# Altium schematic sheet Main0.3.SchDoc(Main0.3)
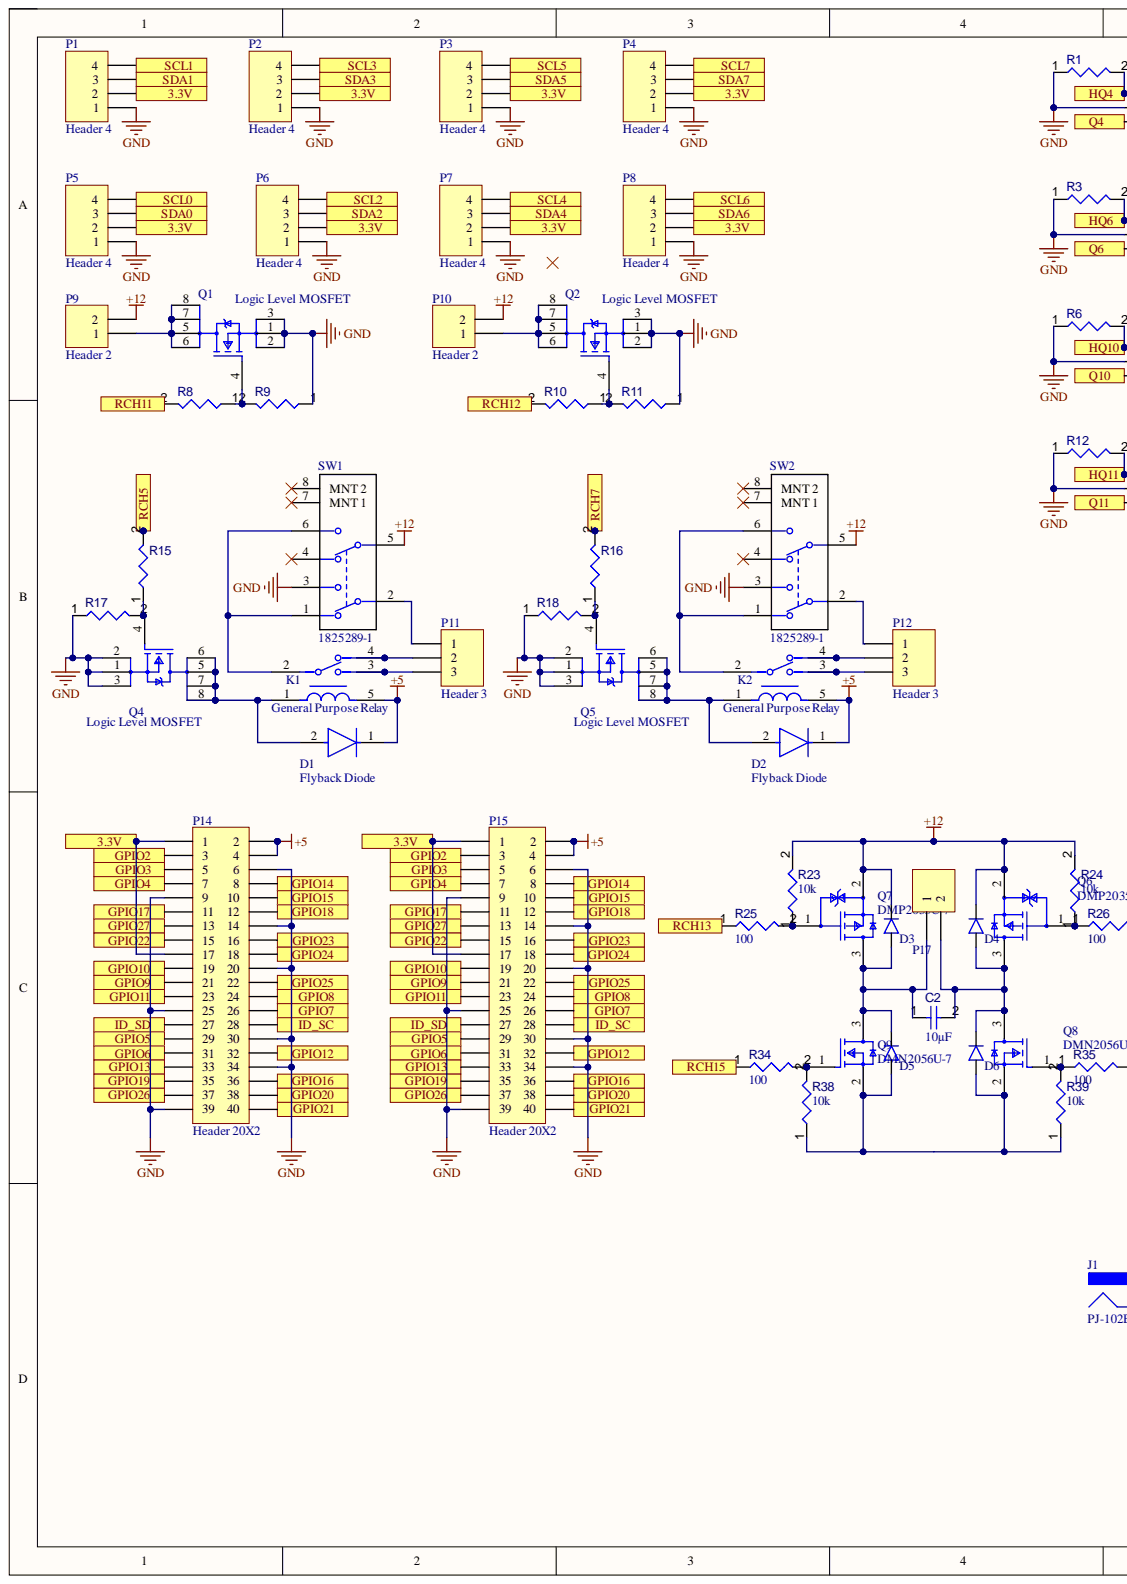
[diagram p0: Main0.3.SchDoc, left]
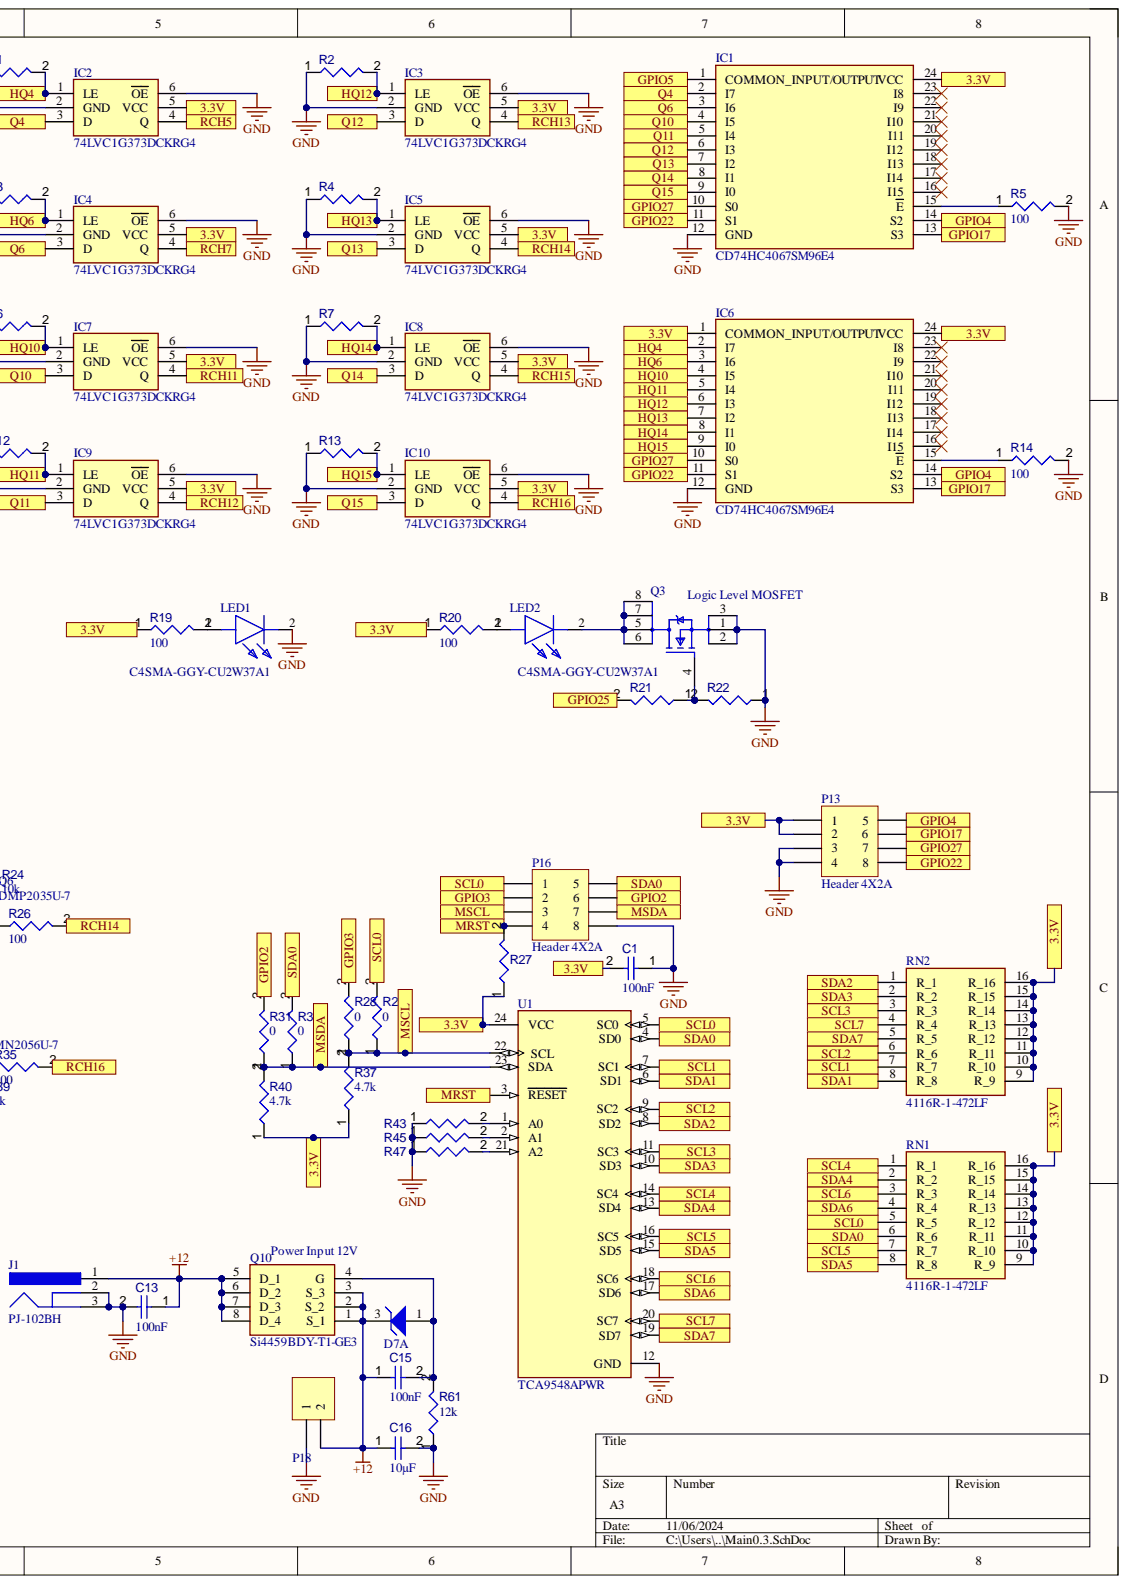
[diagram p1: Main0.3.SchDoc, right]

## Parts
C1  value 100nF  [CAPC2013X145X48ML20T23]  (hidden comment: VJ0805Y104JXXAT)
C2  value 10µF  [FP-0805-L_2_0_0_2-W_1_25-MFG]  (hidden comment: GMK212BBJ106KG-T)
C13  value 100nF  [FP-0805-L_2_01_0_2-W_1_25-IPC_B]  (hidden comment: 08055C104J4T2A)
C15  value 100nF  [CAPC2013X145X48ML20T23]  (hidden comment: VJ0805Y104JXXAT)
C16  value 10µF  [FP-0805-L_2_0_0_2-W_1_25-MFG]  (hidden comment: GMK212BBJ106KG-T)
D1  Flyback Diode  [FP-SOD123F_Type_B-MFG]
D2  Flyback Diode  [FP-SOD123F_Type_B-MFG]
D3  PMEG3020EP_115  [SODFL4725X110N]  (value not drawn)
D4  PMEG3020EP_115  [SODFL4725X110N]  (value not drawn)
D5  PMEG3020EP_115  [SODFL4725X110N]  (value not drawn)
D6  PMEG3020EP_115  [SODFL4725X110N]  (value not drawn)
D7  MMBZ5242BLT3G  [ONSC-SOT-23-3-318-08_V]  (value not drawn)  (part A)
IC1  CD74HC4067SM96E4  [SOP65P780X200-24N]
IC2  74LVC1G373DCKRG4  [SOT65P210X110-6N]
IC3  74LVC1G373DCKRG4  [SOT65P210X110-6N]
IC4  74LVC1G373DCKRG4  [SOT65P210X110-6N]
IC5  74LVC1G373DCKRG4  [SOT65P210X110-6N]
IC6  CD74HC4067SM96E4  [SOP65P780X200-24N]
IC7  74LVC1G373DCKRG4  [SOT65P210X110-6N]
IC8  74LVC1G373DCKRG4  [SOT65P210X110-6N]
IC9  74LVC1G373DCKRG4  [SOT65P210X110-6N]
IC10  74LVC1G373DCKRG4  [SOT65P210X110-6N]
J1  PJ-102BH  [CUI-PJ-102BH_V]
K1  General Purpose Relay  [FP-G5Q-14_DC5-MFG]
K2  General Purpose Relay  [FP-G5Q-14_DC5-MFG]
LED1  C4SMA-GGY-CU2W37A1  [C4SMAGGYCU2W37A1]
LED2  C4SMA-GGY-CU2W37A1  [C4SMAGGYCU2W37A1]
P1  Header 4  [HDR1X4]
P2  Header 4  [HDR1X4]
P3  Header 4  [HDR1X4]
P4  Header 4  [HDR1X4]
P5  Header 4  [HDR1X4]
P6  Header 4  [HDR1X4]
P7  Header 4  [HDR1X4]
P8  Header 4  [HDR1X4]
P9  Header 2  [HDR1X2]
P10  Header 2  [HDR1X2]
P11  Header 3  [HDR1X3]
P12  Header 3  [HDR1X3]
P13  Header 4X2A  [HDR2X4_CEN]
P14  Header 20X2  [HDR2X20]
P15  Header 20X2  [HDR2X20]
P16  Header 4X2A  [HDR2X4_CEN]
P17  Header 2  [HDR1X2]  (value not drawn)
P18  Header 2  [HDR1X2]  (value not drawn)
Q1  Logic Level MOSFET  [FP-PG-DSO-8-IPC_B]
Q2  Logic Level MOSFET  [FP-PG-DSO-8-IPC_B]
Q3  Logic Level MOSFET  [FP-PG-DSO-8-IPC_B]
Q4  Logic Level MOSFET  [FP-PG-DSO-8-IPC_B]
Q5  Logic Level MOSFET  [FP-PG-DSO-8-IPC_B]
Q6  DMP2035U-7  [FP-SOT23-IPC_C]
Q7  DMP2035U-7  [FP-SOT23-IPC_C]
Q8  DMN2056U-7  [FP-SOT23-IPC_C]
Q9  DMN2056U-7  [FP-SOT23-IPC_C]
Q10  Si4459BDY-T1-GE3  [SOIC127P610X323-8N]
R1  100 Kohm 0805  [Chip2PinSM127P600-8N]  (value not drawn)
R2  100 Kohm 0805  [Chip2PinSM127P600-8N]  (value not drawn)
R3  100 Kohm 0805  [Chip2PinSM127P600-8N]  (value not drawn)
R4  100 Kohm 0805  [Chip2PinSM127P600-8N]  (value not drawn)
R5  value 100  [Chip2PinSM127P600-8N]  (hidden comment: RCG0805100RJNEA)
R6  100 Kohm 0805  [Chip2PinSM127P600-8N]  (value not drawn)
R7  100 Kohm 0805  [Chip2PinSM127P600-8N]  (value not drawn)
R8  1 Kohm 0805  [Chip2PinSM127P600-8N]  (value not drawn)
R9  100 Kohm 0805  [Chip2PinSM127P600-8N]  (value not drawn)
R10  1 Kohm 0805  [Chip2PinSM127P600-8N]  (value not drawn)
R11  100 Kohm 0805  [Chip2PinSM127P600-8N]  (value not drawn)
R12  100 Kohm 0805  [Chip2PinSM127P600-8N]  (value not drawn)
R13  100 Kohm 0805  [Chip2PinSM127P600-8N]  (value not drawn)
R14  value 100  [Chip2PinSM127P600-8N]  (hidden comment: RCG0805100RJNEA)
R15  1 Kohm 0805  [Chip2PinSM127P600-8N]  (value not drawn)
R16  1 Kohm 0805  [Chip2PinSM127P600-8N]  (value not drawn)
R17  100 Kohm 0805  [Chip2PinSM127P600-8N]  (value not drawn)
R18  100 Kohm 0805  [Chip2PinSM127P600-8N]  (value not drawn)
R19  value 100  [Chip2PinSM127P600-8N]  (hidden comment: RCG0805100RJNEA)
R20  value 100  [Chip2PinSM127P600-8N]  (hidden comment: RCG0805100RJNEA)
R21  1 Kohm 0805  [Chip2PinSM127P600-8N]  (value not drawn)
R22  100 Kohm 0805  [Chip2PinSM127P600-8N]  (value not drawn)
R23  value 10k  [RESC2013X60X40LL20T25_A]  (hidden comment: ERA-6AEB103V)
R24  value 10k  [RESC2013X60X40LL20T25_A]  (hidden comment: ERA-6AEB103V)
R25  value 100  [Chip2PinSM127P600-8N]  (hidden comment: RCG0805100RJNEA)
R26  value 100  [Chip2PinSM127P600-8N]  (hidden comment: RCG0805100RJNEA)
R27  ERA-6AEB103V  [RESC2013X60X40LL20T25_A]  (value not drawn)
R28  value 0  [FP-MCU0805-MFG]  (hidden comment: MCU08050Z0000ZP500)
R29  value 0  [FP-MCU0805-MFG]  (hidden comment: MCU08050Z0000ZP500)
R31  value 0  [FP-MCU0805-MFG]  (hidden comment: MCU08050Z0000ZP500)
R32  value 0  [FP-MCU0805-MFG]  (hidden comment: MCU08050Z0000ZP500)
R34  value 100  [Chip2PinSM127P600-8N]  (hidden comment: RCG0805100RJNEA)
R35  value 100  [Chip2PinSM127P600-8N]  (hidden comment: RCG0805100RJNEA)
R37  value 4.7k  [FP-CRCW0805-e3-IPC_A]  (hidden comment: CRCW08054K70FKEC)
R38  value 10k  [RESC2013X60X40LL20T25_A]  (hidden comment: ERA-6AEB103V)
R39  value 10k  [RESC2013X60X40LL20T25_A]  (hidden comment: ERA-6AEB103V)
R40  value 4.7k  [FP-CRCW0805-e3-IPC_A]  (hidden comment: CRCW08054K70FKEC)
R43  ERA-6AEB103V  [RESC2013X60X40LL20T25_A]  (value not drawn)
R45  ERA-6AEB103V  [RESC2013X60X40LL20T25_A]  (value not drawn)
R47  ERA-6AEB103V  [RESC2013X60X40LL20T25_A]  (value not drawn)
R61  value 12k  [Chip2PinSM127P600-8N]  (hidden comment: TNPW080512K0BEEA)
RN1  4116R-1-472LF  [DIP787W49P254L2197H469Q16N]
RN2  4116R-1-472LF  [DIP787W49P254L2197H469Q16N]
SW1  1825289-1  [FP-1825289-1-MFG]
SW2  1825289-1  [FP-1825289-1-MFG]
U1  TCA9548APWR  [FP-PW0024A-MFG]

## Ports
3.3V, GPIO2, GPIO3, GPIO4, GPIO5, GPIO6, GPIO7, GPIO8, GPIO9, GPIO10, GPIO11, GPIO12, GPIO13, GPIO14, GPIO15, GPIO16, GPIO17, GPIO18, GPIO19, GPIO20, GPIO21, GPIO22, GPIO23, GPIO24, GPIO25, GPIO26, GPIO27, HQ4, HQ6, HQ10, HQ11, HQ12, HQ13, HQ14, HQ15, ID_SC, ID_SD, MRST, MSCL, MSDA, Q4, Q6, Q10, Q11, Q12, Q13, Q14, Q15, RCH5, RCH7, RCH11, RCH12, RCH13, RCH14, RCH15, RCH16, SCL0, SCL1, SCL2, SCL3, SCL4, SCL5, SCL6, SCL7, SDA0, SDA1, SDA2, SDA3, SDA4, SDA5, SDA6, SDA7

## Nets
+5: D1.1 D2.1 K1.5 K2.5 P14.2 P14.4 P15.2 P15.4
+12: C13.1 C15.1 C16.1 D3.1 D4.1 D7.3 J1.1 P9.2 P10.2 P18.2 Q6.2 Q7.2 Q10.1(S_1) Q10.2(S_2) Q10.3(S_3) Q10.5(D_1) Q10.6(D_2) Q10.7(D_3) Q10.8(D_4) R23.2 R24.2 SW1.5 SW2.5
GND: C1.1 C13.2 C16.2 IC1.12(GND) IC2.2(GND) IC2.6(OE) IC3.2(GND) IC3.6(OE) IC4.2(GND) IC4.6(OE) IC5.2(GND) IC5.6(OE) IC6.12(GND) IC7.2(GND) IC7.6(OE) IC8.2(GND) IC8.6(OE) IC9.2(GND) IC9.6(OE) IC10.2(GND) IC10.6(OE) J1.2 J1.3 LED1.2 P1.1 P2.1 P3.1 P4.1 P5.1 P6.1 P7.1 P8.1 P13.3 P13.4 P14.6 P14.9 P14.14 P14.20 P14.25 P14.30 P14.34 P14.39 P15.6 P15.9 P15.14 P15.20 P15.25 P15.30 P15.34 P15.39 P16.8 P18.1 Q1.1 Q1.2 Q1.3 Q2.1 Q2.2 Q2.3 Q3.1 Q3.2 Q3.3 Q4.1 Q4.2 Q4.3 Q5.1 Q5.2 Q5.3 R1.1 R2.1 R3.1 R4.1 R5.2 R6.1 R7.1 R9.1 R11.1 R12.1 R13.1 R14.2 R17.1 R18.1 R22.1 R43.1 R45.1 R47.1 R61.1 SW1.3 SW2.3 U1.12(GND)
3.3V: C1.2 IC1.24(VCC) IC2.5(VCC) IC3.5(VCC) IC4.5(VCC) IC5.5(VCC) IC6.1(COMMON_INPUT/OUTPUT) IC6.24(VCC) IC7.5(VCC) IC8.5(VCC) IC9.5(VCC) IC10.5(VCC) P1.2 P2.2 P3.2 P4.2 P5.2 P6.2 P7.2 P8.2 P13.1 P13.2 P14.1 P14.17 P15.1 P15.17 R19.1 R20.1 R27.1 R37.1 R40.1 RN1.9(R_9) RN1.10(R_10) RN1.11(R_11) RN1.12(R_12) RN1.13(R_13) RN1.14(R_14) RN1.15(R_15) RN1.16(R_16) RN2.9(R_9) RN2.10(R_10) RN2.11(R_11) RN2.12(R_12) RN2.13(R_13) RN2.14(R_14) RN2.15(R_15) RN2.16(R_16) U1.24(VCC) ; port 3.3V
GPIO2: P14.3 P15.3 P16.6 R31.2 ; port GPIO2
GPIO3: P14.5 P15.5 P16.2 R28.2 ; port GPIO3
GPIO4: IC1.14(S2) IC6.14(S2) P13.5 P14.7 P15.7 ; port GPIO4
GPIO5: IC1.1(COMMON_INPUT/OUTPUT) P14.29 P15.29 ; port GPIO5
GPIO6: P14.31 P15.31 ; port GPIO6
GPIO7: P14.26 P15.26 ; port GPIO7
GPIO8: P14.24 P15.24 ; port GPIO8
GPIO9: P14.21 P15.21 ; port GPIO9
GPIO10: P14.19 P15.19 ; port GPIO10
GPIO11: P14.23 P15.23 ; port GPIO11
GPIO12: P14.32 P15.32 ; port GPIO12
GPIO13: P14.33 P15.33 ; port GPIO13
GPIO14: P14.8 P15.8 ; port GPIO14
GPIO15: P14.10 P15.10 ; port GPIO15
GPIO16: P14.36 P15.36 ; port GPIO16
GPIO17: IC1.13(S3) IC6.13(S3) P13.6 P14.11 P15.11 ; port GPIO17
GPIO18: P14.12 P15.12 ; port GPIO18
GPIO19: P14.35 P15.35 ; port GPIO19
GPIO20: P14.38 P15.38 ; port GPIO20
GPIO21: P14.40 P15.40 ; port GPIO21
GPIO22: IC1.11(S1) IC6.11(S1) P13.8 P14.15 P15.15 ; port GPIO22
GPIO23: P14.16 P15.16 ; port GPIO23
GPIO24: P14.18 P15.18 ; port GPIO24
GPIO25: P14.22 P15.22 R21.2 ; port GPIO25
GPIO26: P14.37 P15.37 ; port GPIO26
GPIO27: IC1.10(S0) IC6.10(S0) P13.7 P14.13 P15.13 ; port GPIO27
HQ4: IC2.1(LE) IC6.2(I7) R1.2 ; port HQ4
HQ6: IC4.1(LE) IC6.3(I6) R3.2 ; port HQ6
HQ10: IC6.4(I5) IC7.1(LE) R6.2 ; port HQ10
HQ11: IC6.5(I4) IC9.1(LE) R12.2 ; port HQ11
HQ12: IC3.1(LE) IC6.6(I3) R2.2 ; port HQ12
HQ13: IC5.1(LE) IC6.7(I2) R4.2 ; port HQ13
HQ14: IC6.8(I1) IC8.1(LE) R7.2 ; port HQ14
HQ15: IC6.9(I0) IC10.1(LE) R13.2 ; port HQ15
ID_SC: P14.28 P15.28 ; port ID_SC
ID_SD: P14.27 P15.27 ; port ID_SD
MRST: P16.4 R27.2 U1.3(RESET) ; port MRST
MSCL: P16.3 R28.1 R29.1 R37.2 U1.22(SCL) ; port MSCL
MSDA: P16.7 R31.1 R32.1 R40.2 U1.23(SDA) ; port MSDA
Q4: IC1.2(I7) IC2.3(D) ; port Q4
Q6: IC1.3(I6) IC4.3(D) ; port Q6
Q10: IC1.4(I5) IC7.3(D) ; port Q10
Q11: IC1.5(I4) IC9.3(D) ; port Q11
Q12: IC1.6(I3) IC3.3(D) ; port Q12
Q13: IC1.7(I2) IC5.3(D) ; port Q13
Q14: IC1.8(I1) IC8.3(D) ; port Q14
Q15: IC1.9(I0) IC10.3(D) ; port Q15
RCH5: IC2.4(Q) R15.2 ; port RCH5
RCH7: IC4.4(Q) R16.2 ; port RCH7
RCH11: IC7.4(Q) R8.2 ; port RCH11
RCH12: IC9.4(Q) R10.2 ; port RCH12
RCH13: IC3.4(Q) R25.1 ; port RCH13
RCH14: IC5.4(Q) R26.2 ; port RCH14
RCH15: IC8.4(Q) R34.1 ; port RCH15
RCH16: IC10.4(Q) R35.2 ; port RCH16
SCL0: P5.4 P16.1 R29.2 RN1.5(R_5) U1.5(SC0) ; port SCL0
SCL1: P1.4 RN2.7(R_7) U1.7(SC1) ; port SCL1
SCL2: P6.4 RN2.6(R_6) U1.9(SC2) ; port SCL2
SCL3: P2.4 RN2.3(R_3) U1.11(SC3) ; port SCL3
SCL4: P7.4 RN1.1(R_1) U1.14(SC4) ; port SCL4
SCL5: P3.4 RN1.7(R_7) U1.16(SC5) ; port SCL5
SCL6: P8.4 RN1.3(R_3) U1.18(SC6) ; port SCL6
SCL7: P4.4 RN2.4(R_4) U1.20(SC7) ; port SCL7
SDA0: P5.3 P16.5 R32.2 RN1.6(R_6) U1.4(SD0) ; port SDA0
SDA1: P1.3 RN2.8(R_8) U1.6(SD1) ; port SDA1
SDA2: P6.3 RN2.1(R_1) U1.8(SD2) ; port SDA2
SDA3: P2.3 RN2.2(R_2) U1.10(SD3) ; port SDA3
SDA4: P7.3 RN1.2(R_2) U1.13(SD4) ; port SDA4
SDA5: P3.3 RN1.8(R_8) U1.15(SD5) ; port SDA5
SDA6: P8.3 RN1.4(R_4) U1.17(SD6) ; port SDA6
SDA7: P4.3 RN2.5(R_5) U1.19(SD7) ; port SDA7
NetC2_1: C2.1 D3.2 D5.1 P17.1 Q7.3 Q9.3
NetC2_2: C2.2 D4.2 D6.1 P17.2 Q6.3 Q8.3
NetC15_2: C15.2 D7.1 Q10.4(G) R61.2
NetD1_2: D1.2 K1.1 Q4.5 Q4.6 Q4.7 Q4.8
NetD2_2: D2.2 K2.1 Q5.5 Q5.6 Q5.7 Q5.8
NetD5_2: D5.2 D6.2 Q8.2 Q9.2 R38.1 R39.1
NetIC1_15: IC1.15(E) R5.1
NetIC6_15: IC6.15(E) R14.1
NetK1_2: K1.2 SW1.1 SW1.6
NetK1_3: K1.3 P11.3
NetK1_4: K1.4 P11.2
NetK2_2: K2.2 SW2.1 SW2.6
NetK2_3: K2.3 P12.3
NetK2_4: K2.4 P12.2
NetLED1_1: LED1.1 R19.2
NetLED2_1: LED2.1 R20.2
NetLED2_2: LED2.2 Q3.5 Q3.6 Q3.7 Q3.8
NetP9_1: P9.1 Q1.5 Q1.6 Q1.7 Q1.8
NetP10_1: P10.1 Q2.5 Q2.6 Q2.7 Q2.8
NetP11_1: P11.1 SW1.2
NetP12_1: P12.1 SW2.2
NetQ1_4: Q1.4 R8.1 R9.2
NetQ2_4: Q2.4 R10.1 R11.2
NetQ3_4: Q3.4 R21.1 R22.2
NetQ4_4: Q4.4 R15.1 R17.2
NetQ5_4: Q5.4 R16.1 R18.2
NetQ6_1: Q6.1 R24.1 R26.1
NetQ7_1: Q7.1 R23.1 R25.2
NetQ8_1: Q8.1 R35.1 R39.2
NetQ9_1: Q9.1 R34.2 R38.2
NetR43_2: R43.2 U1.1(A0)
NetR45_2: R45.2 U1.2(A1)
NetR47_2: R47.2 U1.21(A2)

Not connected: IC1 16(I15) 17(I14) 18(I13) 19(I12) 20(I11) 21(I10) 22(I9) 23(I8); IC6 16(I15) 17(I14) 18(I13) 19(I12) 20(I11) 21(I10) 22(I9) 23(I8); SW1 4 7(MNT_1) 8(MNT_2); SW2 4 7(MNT_1) 8(MNT_2)

## Library data of the parts
C1 C15 = CMP-001-00004-1: Ceramic Capacitor, Multilayer, Ceramic, 25V, 5% +Tol, 5% -Tol, X7R, 15% TC, 0.1uF, Surface Mount, 0805; Capacitor Type: Ceramic; Case/Package: 0805; Component Kind: Standard; Max Operating Temperature: 125°C; Min Operating Temperature: -55°C; Mounting Technology: Surface Mount; Pins: 2; RoHS Compliant: Yes; Library Name: Liam Personal
C2 C16 = CMP-001-00008-1: Ceramic Capacitor, Multilayer, Ceramic, 35V, 10% +Tol, 10% -Tol, X5R, 15% TC, 10uF, Surface Mount, 0805; Capacitor Type: Ceramic; Case/Package: 0805; Component Kind: Standard; Max Operating Temperature: 85°C; Min Operating Temperature: -55°C; Mounting Technology: Surface Mount; Pins: 2; RoHS Compliant: Yes; Library Name: Liam Personal
C13 = CMP-001-00009-1: Ceramic Capacitor, Multilayer, Ceramic, 50V, 5% +Tol, 5% -Tol, X7R, 15% TC, 0.1uF, Surface Mount, 0805; Capacitor Type: Ceramic; Case/Package: 0805; Component Kind: Standard; Max Operating Temperature: 125°C; Min Operating Temperature: -55°C; Mounting Technology: Surface Mount; Pins: 2; RoHS Compliant: Yes; Library Name: Liam Personal
D1 D2 = CMP-004-00000-1: Rectifier Diode, 1 Phase, 1 Element, 1A, 40V V(RRM), Silicon; Component Kind: Standard; Max Operating Temperature: 125°C; Min Operating Temperature: -55°C; Pins: 2; RoHS Compliant: Yes; Library Name: Liam Personal
D3-D6 = CMP-004-00002-3: Schottky Diodes & Rectifiers SCHOTTKY RECT 30V 2A; Manufacturer_Name: Nexperia; Manufacturer_Part_Number: PMEG3020EP,115; Geometry.Height: 1.1mm; Component Kind: Standard; Datasheet Link: https://assets.nexperia.com/documents/data-sheet/PMEG3020EP.pdf; Design Item ID: PMEG3020EP_115; Mouser Part Number: 771-PMEG3020EP115; Mouser Price/Stock: https://www.mouser.co.uk/ProductDetail/Nexperia/PMEG3020EP115?qs=GcY6OjH1zdnlY%252B06tUqEyw%3D%3D; Source: PMEG3020EP_115.SchLib; Library Name: Liam Personal
D7 = CMP-013-00001-2: Zener Diode, 12V V(Z), 5%, 0.225W, Silicon, Unidirectional, TO-236AB; Component Kind: Standard; Max Operating Temperature: 150°C; Min Operating Temperature: -65°C; Pins: 3; RoHS Compliant: Yes; Library Name: Liam Personal
IC1 IC6 = CD74HC4067SM96E4: Integrated Circuit; Manufacturer_Name: Texas Instruments; Arrow Part Number: CD74HC4067SM96E4; Arrow Price/Stock: https://www.arrow.com/en/products/cd74hc4067sm96e4/texas-instruments; Component Kind: Standard; Datasheet Link: https://www.ti.com/lit/ds/symlink/cd74hc4067.pdf?HQS=TI-null-null-digikeymode-df-pf-null-wwe&ts=1600239369706; Height: 2mm; Manufacturer_Part_Number: CD74HC4067SM96E4; Mouser Part Number: 595-CD74HC4067SM96E4; Mouser Price/Stock: https://www.mouser.co.uk/ProductDetail/Texas-Instruments/CD74HC4067SM96E4?qs=xFfolx0DHx10mQs51D22AA%3D%3D
IC2-IC5 IC7-IC10 = 74LVC1G373DCKRG4: Integrated Circuit; Manufacturer_Name: Texas Instruments; Component Kind: Standard; Datasheet Link: http://www.ti.com/lit/gpn/SN74LVC1G373; Height: 1.1mm; Manufacturer_Part_Number: 74LVC1G373DCKRG4; Mouser Part Number: 595-74LVC1G373DCKRG4; Mouser Price/Stock: https://www.mouser.co.uk/ProductDetail/Texas-Instruments/74LVC1G373DCKRG4?qs=KnqljqdDuvcGLFC7XkHsHg%3D%3D
J1 = CMP-00030-00001-1: DC Power Plug or Jack; Component Kind: Standard; Library Name: Liam Personal
K1 K2 = CMP-008-00001-1: Power/Signal Relay, 1 Form C, SPDT, Momentary, 0.08A (Coil), 9.5VDC (Coil), 400mW (Coil), 5A (Contact), 30VDC (Contact), DC Input, Random, AC/DC Output, Through Hole-Straight Mount; Operate Time: 10ms; Component Kind: Standard; Max Operating Temperature: 105°C; Min Operating Temperature: -40°C; Mounting Technology: Through Hole; Pins: 5; RoHS Compliant: Yes; Library Name: Liam Personal
LED1 LED2 = C4SMA-GGY-CU2W37A1: LED; Manufacturer_Name: CREE LED; Component Kind: Standard; Datasheet Link: https://cree-led.com/media/documents/C4SMA-1442.pdf; Height: 8.8mm; Manufacturer_Part_Number: C4SMA-GGY-CU2W37A1; Mouser Part Number: 941-C4SMAGGYCU2W37A1; Mouser Price/Stock: https://www.mouser.co.uk/ProductDetail/Cree-LED/C4SMA-GGY-CU2W37A1?qs=7D1LtPJG0i1gnYL5Rh9Mjg%3D%3D
P1-P8 = CMP-002-00125-1: Header, 4-Pin; Component Kind: Standard; Component Type: Standard; Design Item ID: Header 4; LatestRevisionDate: 17-Jul-2002; LatestRevisionNote: Re-released for DXP Platform.; Pins: 4; Signal Integrity: Connector; Source: Miscellaneous Connectors.SchLib; Library Name: Liam Personal; Publisher: Altium Limited
P9 P10 P17 P18 = CMP-002-00098-1: Header, 2-Pin; Component Kind: Standard; Component Type: Standard; Design Item ID: Header 2; LatestRevisionDate: 17-Jul-2002; LatestRevisionNote: Re-released for DXP Platform.; Pins: 2; Signal Integrity: Connector; Source: Miscellaneous Connectors.SchLib; Library Name: Liam Personal; Publisher: Altium Limited
P11 P12 = CMP-002-00118-1: Header, 3-Pin; Component Kind: Standard; Component Type: Standard; Design Item ID: Header 3; LatestRevisionDate: 17-Jul-2002; LatestRevisionNote: Re-released for DXP Platform.; Pins: 3; Signal Integrity: Connector; Source: Miscellaneous Connectors.SchLib; Library Name: Liam Personal; Publisher: Altium Limited
P13 P16 = CMP-002-00128-1: Header, 4-Pin, Dual row; Component Kind: Standard; Component Type: Standard; Design Item ID: Header 4X2A; LatestRevisionDate: 17-Jul-2002; LatestRevisionNote: Re-released for DXP Platform.; Pins: 8; Signal Integrity: Connector; Source: Miscellaneous Connectors.SchLib; Library Name: Liam Personal; Publisher: Altium Limited
P14 P15 = CMP-002-00101-1: Header, 20-Pin, Dual row; Component Kind: Standard; Component Type: Standard; Design Item ID: Header 20X2; LatestRevisionDate: 17-Jul-2002; LatestRevisionNote: Re-released for DXP Platform.; Pins: 40; Signal Integrity: Connector; Source: Miscellaneous Connectors.SchLib; Library Name: Liam Personal; Publisher: Altium Limited
Q1-Q5 = CMP-016-00000-1: Power Field-Effect Transistor, 10A I(D), 40V, 0.013ohm, 1-Element, N-Channel, Silicon, Metal-oxide Semiconductor FET, MS-012AA IRF7470TRPBF; Component Kind: Standard; Pins: 8; RoHS Compliant: Yes; Library Name: Liam Personal
Q6 Q7 = CMP-013-00003-1: Small Signal Field-Effect Transistor, 3.6A I(D), 20V, 1-Element, P-Channel, Silicon, Metal-oxide Semiconductor FET; Component Kind: Standard; Max Operating Temperature: 150°C; Pins: 3; RoHS Compliant: Yes; Library Name: Liam Personal
Q8 Q9 = CMP-013-00002-1: Small Signal Field-Effect Transistor, 4A I(D), 20V, 1-Element, N-Channel, Silicon, Metal-oxide Semiconductor FET; Component Kind: Standard; RoHS Compliant: Yes; Library Name: Liam Personal
Q10 = Si4459BDY-T1-GE3: MOSFET (P-Channel); Manufacturer_Name: Vishay; Arrow Part Number: SI4459BDY-T1-GE3; Arrow Price/Stock: https://www.arrow.com/en/products/si4459bdy-t1-ge3/vishay?region=asia; Component Kind: Standard; Datasheet Link: https://componentsearchengine.com/Datasheets/5/SI4459BDY-T1-GE3.pdf; Height: 3.23mm; Manufacturer_Part_Number: Si4459BDY-T1-GE3; Mouser Part Number: 78-SI4459BDY-T1-GE3; Mouser Price/Stock: https://www.mouser.co.uk/ProductDetail/Vishay-Semiconductors/SI4459BDY-T1-GE3?qs=lYGu3FyN48dPMSySH5KPIg%3D%3D
R1-R4 R6 R7 R9 R11-R13 R17 R18 R22 = CMP-009-00007-2: Res Thin Film 0805 100K Ohm 0.1% 0.25W(1/4W) ±25ppm/C Sulfur Resistant Pad SMD T/R Automotive; Component Kind: Standard; Library Name: Liam Personal
R5 R14 R19 R20 R25 R26 R34 R35 = CMP-009-00016-1: Fixed Resistor, Metal Glaze/thick Film, 0.125W, 100ohm, 150V, 5% +/-Tol, 200ppm/Cel, Surface Mount, 0805; Power: 125mW; Case/Package: 0805; Component Kind: Standard; Max Operating Temperature: 155°C; Min Operating Temperature: -55°C; Pins: 2; RoHS Compliant: Yes; Tolerance: 5%; Library Name: Liam Personal
R8 R10 R15 R16 R21 = CMP-009-00008-3: Res Thin Film 0805 1K Ohm 0.1% 0.25W(1/4W) ±25ppm/C Sulfur Resistant Pad SMD Automotive T/R; Component Kind: Standard; Library Name: Liam Personal
R23 R24 R38 R39 = CMP-009-00001-1: Fixed Resistor, Thin Film, 0.125W, 10000ohm, 100V, 0.1% +/-Tol, 25ppm/Cel, Surface Mount, 0805; Power: 125mW; Case/Package: 0805; Component Kind: Standard; Max Operating Temperature: 155°C; Min Operating Temperature: -55°C; Mounting Technology: Surface Mount; Pins: 2; RoHS Compliant: Yes; Tolerance: 0.1%; Library Name: Liam Personal
R27 R43 R45 R47 = CMP-009-00001-1: Fixed Resistor, Thin Film, 0.125W, 10000ohm, 100V, 0.1% +/-Tol, 25ppm/Cel, Surface Mount, 0805; Power: 125mW; Value: 10k; Case/Package: 0805; Component Kind: Standard; Max Operating Temperature: 155°C; Min Operating Temperature: -55°C; Mounting Technology: Surface Mount; Pins: 2; RoHS Compliant: Yes; Tolerance: 0.1%; Library Name: Liam Personal
R28 R29 R31 R32 = CMP-009-00018-1: Fixed Resistor, Thin Film, 0.125W, 0ohm, Surface Mount, 0805; Power: 125mW; Case/Package: 0805; Component Kind: Standard; Max Operating Temperature: 125°C; Min Operating Temperature: -55°C; Mounting Technology: Surface Mount; Pins: 2; RoHS Compliant: Yes; Library Name: Liam Personal
R37 R40 = CMP-009-00019-1: Fixed Resistor, Metal Glaze/thick Film, 0.125W, 4700ohm, 150V, 1% +/-Tol, 100ppm/Cel, Surface Mount, 0805; Power: 125mW; Case/Package: 0805; Component Kind: Standard; Max Operating Temperature: 155°C; Min Operating Temperature: -55°C; Mounting Technology: Surface Mount; Pins: 2; RoHS Compliant: Yes; Tolerance: 1%; Library Name: Liam Personal
R61 = CMP-009-00012-1: Fixed Resistor, Thin Film, 0.125W, 12000ohm, 150V, 0.1% +/-Tol, 25ppm/Cel, Surface Mount, 0805; Power: 125mW; Case/Package: 0805; Component Kind: Standard; Max Operating Temperature: 125°C; Min Operating Temperature: -55°C; Mounting Technology: Surface Mount; Pins: 2; RoHS Compliant: Yes; Tolerance: 0.1%; Library Name: Liam Personal
RN1 RN2 = 4116R-1-472LF: Resistor Network; Manufacturer_Name: Bourns; Arrow Part Number: 4116R-1-472LF; Arrow Price/Stock: https://www.arrow.com/en/products/4116r-1-472lf/bourns; Component Kind: Standard; Datasheet Link: http://www.bourns.com/pdfs/4100R.pdf; Height: 4.69mm; Manufacturer_Part_Number: 4116R-1-472LF; Mouser Part Number: 652-4116R-1LF-4.7K; Mouser Price/Stock: https://www.mouser.co.uk/ProductDetail/Bourns/4116R-1-472LF?qs=%252BWt%2Fy7Tool7XyRK9T2NjOw%3D%3D
SW1 SW2 = CMP-011-00000-1: Slide Switch, DPDT, Latched, 0.3A, Solder Terminal, Through Hole-straight; Component Kind: Standard; Max Operating Temperature: 85°C; Min Operating Temperature: -20°C; Mounting Technology: Through Hole; RoHS Compliant: Yes; Library Name: Liam Personal
U1 = CMP-015-00000-1: Multiplexer, 9548 Series, 1-Func, 1 Line Input, 8 Line Output, True Output, PDSO24; Max Supply Voltage: 5.5V; Min Supply Voltage: 1.65V; Nominal Supply Voltage: 1.8V; Case/Package: TSSOP; Component Kind: Standard; Max Operating Temperature: 85°C; Min Operating Temperature: -40°C; Pins: 24; RoHS Compliant: Yes; Library Name: Liam Personal
